# Altium schematic sheet SWG.SchDoc(SWG)
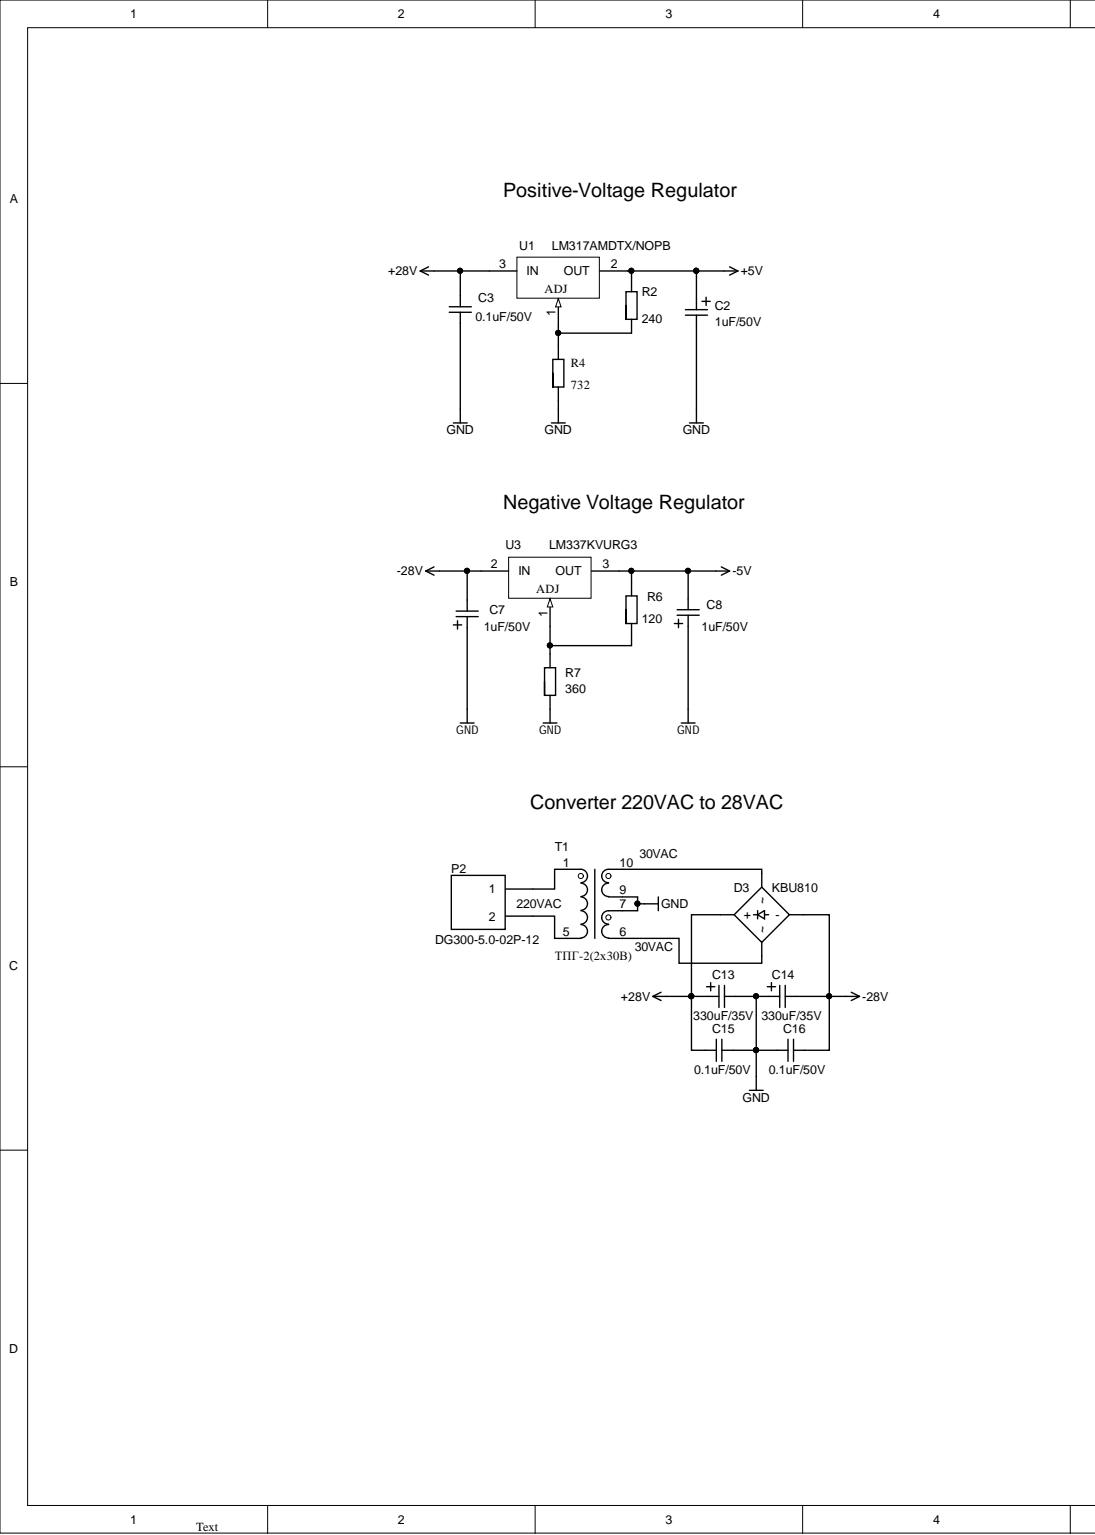
[diagram p0: SWG.SchDoc, left]
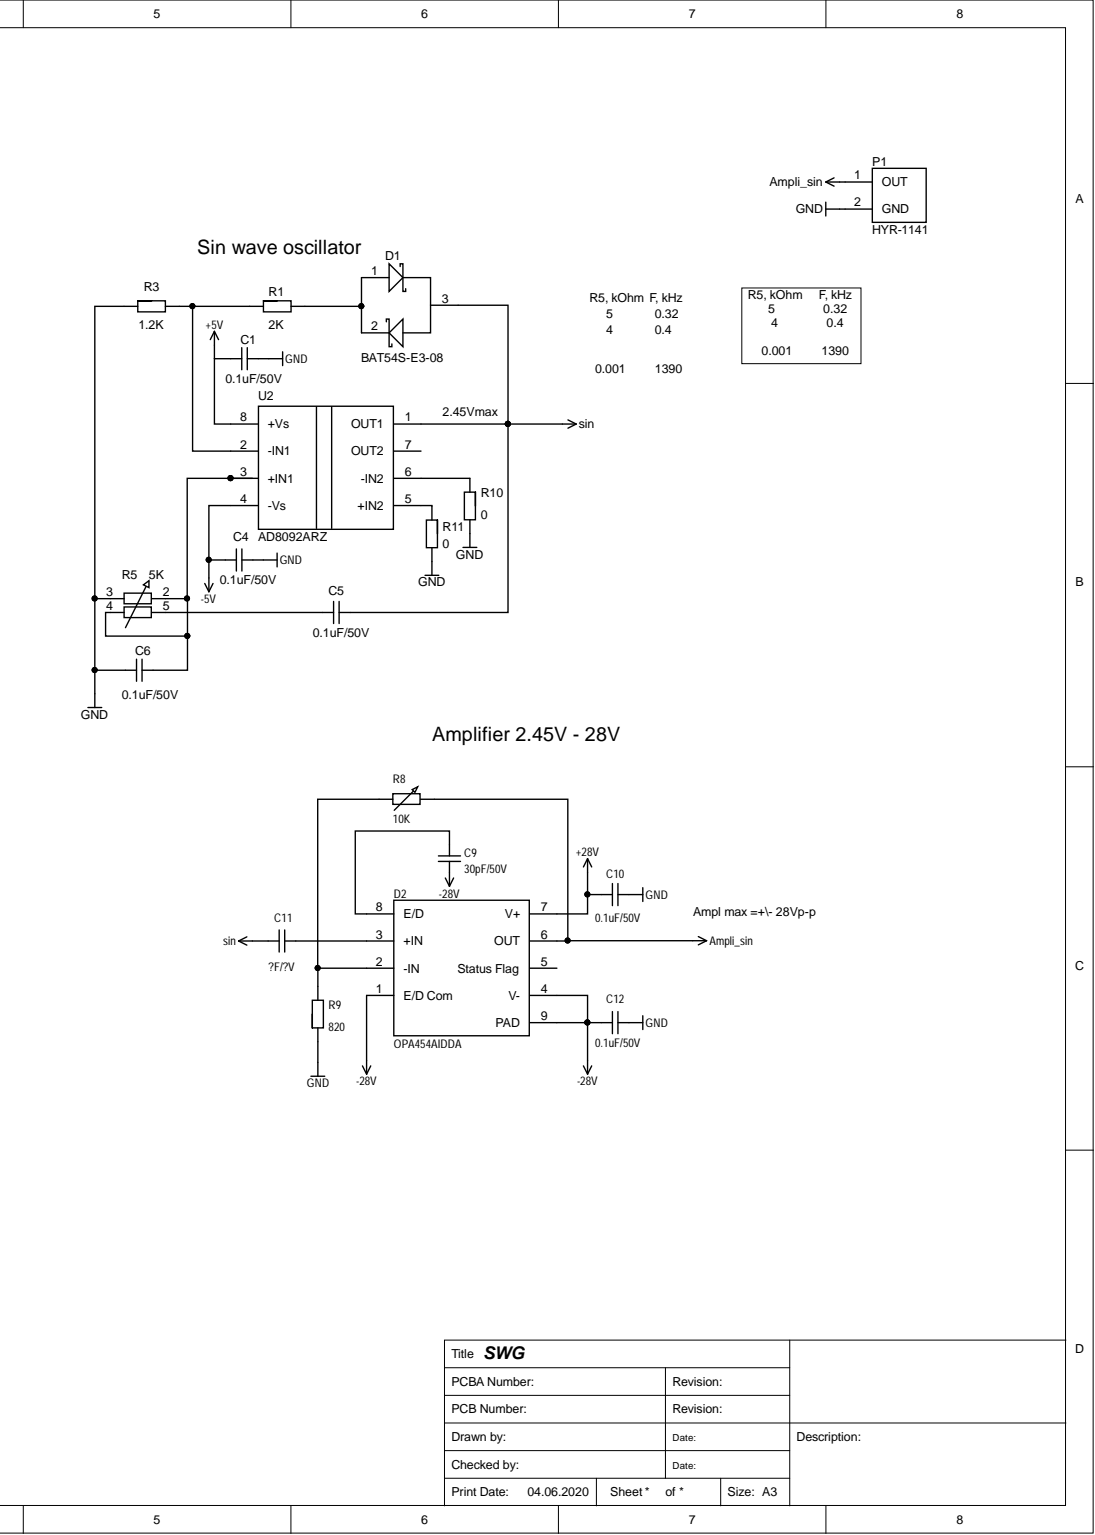
[diagram p1: SWG.SchDoc, right]

## Parts
C1  0.1uF/50V  [C0603]
C2  1uF/50V  [UCHEMI-CON_CAP0404_RAD]
C3  0.1uF/50V  [C0603]
C4  0.1uF/50V  [C0603]
C5  0.1uF/50V  [C0603]
C6  0.1uF/50V  [C0603]
C7  1uF/50V  [UCHEMI-CON_CAP0404_RAD]
C8  1uF/50V  [UCHEMI-CON_CAP0404_RAD]
C9  30pF/50V  [C0603]
C10  0.1uF/50V  [C0603]
C11  ?F/?V  [C0603]
C12  0.1uF/50V  [C0603]
C13  330uF/35V  [UCHEMI-CON_CAP1010_RAD]
C14  330uF/35V  [UCHEMI-CON_CAP1010_RAD]
C15  0.1uF/50V  [C0603]
C16  0.1uF/50V  [C0603]
D1  BAT54S-E3-08  [SOT23-3L]
D2  OPA454AIDDA  [TI_8SOICPAD]
D3  KBU810  [KBU8]
P1  HYR-1141  [SMA]
P2  DG300-5.0-02P-12  [DG300-5.0-02P-12]
R1  2K  [R0603]
R2  240  [R0603]
R3  1.2K  [R0603]
R4  732  [R0603]
R5  5K  [RES_Adj_duble_5K]
R6  120  [R0603]
R7  360  [R0603]
R8  10K  [RES_Adj_10K]
R9  820  [R0603]
R10  0  [R0603]
R11  0  [R0603]
T1  ТПГ-2(2х30В)  [ТПГ-2 (2x30)]
U1  LM317AMDTX/NOPB  [SC_TO-252A]
U2  AD8092ARZ  [AD_SOIC-8_R-8_Rev4]
U3  LM337KVURG3  [SC_TO-252A]

## Nets
+5V: C1.1 C2.1 R2.2 U1.2 U2.8(+Vs)
+28V: C3.2 C10.1 C13.1 C15.1 D2.7(V+) D3.1(+) U1.3
-5V: C4.1 C8.2 R6.2 U2.4(-Vs) U3.3
-28V: C7.2 C9.2 C12.1 C14.2 C16.2 D2.1(E/D_Com) D2.4(V-) D2.9(PAD) D3.4(-) U3.2
Ampli_sin: D2.6(OUT) P1.1 R8.2
GND: C1.2 C2.2 C3.1 C4.2 C6.1 C7.1 C8.1 C10.2 C12.2 C13.2 C14.1 C15.2 C16.1 P1.2 R3.1 R4.1 R5.3 R7.1 R9.1 R10.2 R11.2 T1.7 T1.9
sin: C5.2 C11.1 D1.3 U2.1(OUT1)
NetC5_1: C5.1 R5.5
NetC6_2: C6.2 R5.2 R5.4 U2.3(+IN1)
NetC9_1: C9.1 D2.8(E/D)
NetC11_2: C11.2 D2.3(+IN)
NetD1_1: D1.1 D1.2 R1.2
NetD2_2: D2.2(-IN) R8.1 R9.2
NetD3_2: D3.2(~) T1.10
NetD3_3: D3.3(~) T1.6
NetP2_1: P2.1 T1.1
NetP2_2: P2.2 T1.5
NetR1_1: R1.1 R3.2 U2.2(-IN1)
NetR2_1: R2.1 R4.2 U1.1
NetR6_1: R6.1 R7.2 U3.1
NetR10_1: R10.1 U2.6(-IN2)
NetR11_1: R11.1 U2.5(+IN2)
30VAC:

Not connected: D2 5(Status_Flag); U2 7(OUT2)

## Library data of the parts
C1 C3 C4 C6 = CAP_0603_0.1uF/50V: PartNumber: GRM188R71H104KA93D; Manufacturer: Murata Manufacturing; Group: CAP; Value: 0.1uF/50V; Package: 0603; Component Kind: Standard; Library Name: Schlib_SIN_GEN.SchLib
C2 C7 C8 = CAP_electrolit_1uf: PartNumber: JCK1H010M040054; Manufacturer: JB; Group: CAP; Value: 1uF/50V; Component Kind: Standard; Library Name: Schlib_SIN_GEN.SchLib
C5 C10 C12 C15 C16 = CAP_0603_0.1F/50V: PartNumber: GRM188R71H104KA93D; Manufacturer: Murata Manufacturing; Group: CAP; Value: 0.1uF/50V; Package: 0603; Component Kind: Standard; Library Name: Schlib_SIN_GEN.SchLib
C9 = CAP_0603_30pF/50V: PartNumber: GRM2165C1H300JZ01D; Manufacturer: Murata Manufacturing; Group: CAP; Value: 30pF/50V; Package: 0603; Component Kind: Standard; Library Name: Schlib_SIN_GEN.SchLib
C11 = CAP_0603_?F/?V: Manufacturer: Murata Manufacturing; Group: CAP; Value: ?F/?V; PartNumber: ?; Package: 0603; Component Kind: Standard; Library Name: Schlib_SIN_GEN.SchLib
C13 C14 = CAP_electrolit_330uf: PartNumber: JCK1V331M100105; Manufacturer: JB; Group: CAP; Value: 330uF/35V; Component Kind: Standard; Library Name: Schlib_SIN_GEN.SchLib
D1 = Diode BAT54S: Dual Schottky Rectifier, SOT-23, 30V 200mA; Manufacturer: Vishay; Group: DIOD; PartNumber: BAT54S-E3-08; Package: SOT23; ComponentLink2URL: http://www.irf.com/product-info/datasheets/data/10tq.pdf; ComponentLink2Description: Datasheet; ComponentLink1URL: http://www.irf.com/; ComponentLink1Description: Manufacturer Link; Component Kind: Standard; Library Name: Schlib_SIN_GEN.SchLib
D2 = CH_OPA454AIDDA: IC OPAMP GP 1 CIRCUIT 8SOPWRPAD; Manufacturer: Texas Instruments; Group: CH; PartNumber: OPA454AIDDA; Component Kind: Standard; Library Name: Schlib_SIN_GEN.SchLib
D3 = KBU810: KBU8 series bridge single-phase rectifier, 8А, 1000V; Manufacturer: China; Group: DIOD; PartNumber: KBU810; Library Name: Schlib_SIN_GEN.SchLib; Component Kind: Standard
P1 = SMA: Manufacturer: New Centress (HOYUTEC); Group: CON; PartNumber: HYR-1141; Component Kind: Standard; Library Name: Schlib_SIN_GEN.SchLib
P2 = DG300-5.0-02P-12: Manufacturer: Degson Electronics; Group: CON; PartNumber: DG300-5.0-02P-12; Component Kind: Standard; Library Name: Schlib_SIN_GEN.SchLib
R1 = RES_0603_2K: PartNumber: RC0603FR-0744K2L; Manufacturer: Yageo; Group: RES; Value: 2K; Package: 0603; Component Kind: Standard; Library Name: Schlib_SIN_GEN.SchLib
R2 = RES_0402_240: RES SMD 240 OHM 1% 1/10W 0603; PartNumber: ERJ-3EKF2400V; Manufacturer: Panasonic Electronic Components; Value: 240; Tolerance: ±1%; Package: 0603; Group: RES; Component Kind: Standard; Library Name: SWG.SchLib
R3 = RES_0603_1.2K: PartNumber: RC0603FR-071K2L; Manufacturer: Yageo; Group: RES; Value: 1.2K; Package: 0603; Component Kind: Standard; Library Name: Schlib_SIN_GEN.SchLib
R4 = RES_0402_732: RES SMD 732 OHM 1% 1/10W 0603; PartNumber: ERJ-3EKF7320V; Manufacturer: Panasonic Electronic Components; Value: 732; Tolerance: ±1%; Package: 0603; Group: RES; Component Kind: Standard; Library Name: SWG.SchLib
R5 = RES_Adj_duble_5K: Dual variable resistor WH148-2, resistance nominal 5kom. Metal handle with a recess for the cap.; Manufacturer: China; Group: RES; Value: 5K; PartNumber: WH148-2; Component Kind: Standard; Library Name: Schlib_SIN_GEN.SchLib
R6 = RES_0402: PartNumber: ERJ-2RKF1200X; Manufacturer: Panasonic Electronic Components Panasonic Electronic Components; Tolerance: ±1%; Group: RES; Value: 120; RoHS: ROHS3 Compliant; Package: 0603; Component Kind: Standard; Library Name: SWG.SchLib
R7 = RES_0402: RES SMD 360 OHM 1% 1/10W 0603; PartNumber: ERJ-3EKF3600V; Manufacturer: Panasonic Electronic Components; Value: 360; Tolerance: ±1%; Package: 0603; Group: RES; Component Kind: Standard; Library Name: SWG.SchLib
R8 = Res_Adj_10K: Variable Resistor; PartNumber: 16K1-10C10K,L15KC; Manufacturer: China; Group: RES; Value: 10K; Component Kind: Standard; Library Name: Schlib_SIN_GEN.SchLib
R9 = RES_0603_820: PartNumber: RC0603FR-07820RL; Manufacturer: Yageo; Group: RES; Value: 820; Package: 0603; Component Kind: Standard; Library Name: Schlib_SIN_GEN.SchLib
R10 R11 = RES_0603_0: PartNumber: RC0603JR-070RL; Manufacturer: Yageo; Group: RES; Value: 0; Package: 0603; Component Kind: Standard; Library Name: Schlib_SIN_GEN.SchLib
T1 = ТПГ-2 (2x30): Sealed transformer; Manufacturer: Комплекс; Group: TRANSF; PartNumber: ТПГ-2(2х30В); Component Kind: Standard; Library Name: Schlib_SIN_GEN.SchLib
U1 = LM317AMDTX/NOPB: 3-Terminal Adjustable Regulator; Manufacturer: Texas Instruments; Group: CH; PartNumber: LM317AMDTX/NOPB; Package: TO-252A; ComponentLink3URL: http://www.national.com/packaging/folders/t03b.html; ComponentLink3Description: T03B Package Page; ComponentLink2URL: http://www.national.com/pf/LM/LM317.html; ComponentLink2Description: LM317 Web Page; ComponentLink1URL: http://www.national.com/ds/LM/LM317.pdf; ComponentLink1Description: LM317 Datasheet; Component Kind: Standard; Library Name: Schlib_SIN_GEN.SchLib
U2 = AD8092ARZ_1: Manufacturer: Analog Devices; Group: CH; PartNumber: AD8092ARZ; Component Kind: Standard; Library Name: Schlib_SIN_GEN.SchLib
U3 = LM337KVURG3: 3-Terminal Adjustable Negative Regulator; Manufacturer: Texas Instruments; Group: CH; PartNumber: LM337KVURG3; Package: TO-252A; ComponentLink3URL: http://www.national.com/packaging/folders/t03b.html; ComponentLink3Description: T03B Package Page; ComponentLink2URL: http://www.national.com/pf/LM/LM337.html; ComponentLink2Description: LM337 Web Page; ComponentLink1URL: http://www.national.com/ds/LM/LM337.pdf; ComponentLink1Description: LM337 Datasheet; Component Kind: Standard; Library Name: Schlib_SIN_GEN.SchLib
